# Altium schematic sheet Measuring_Current.SchDoc
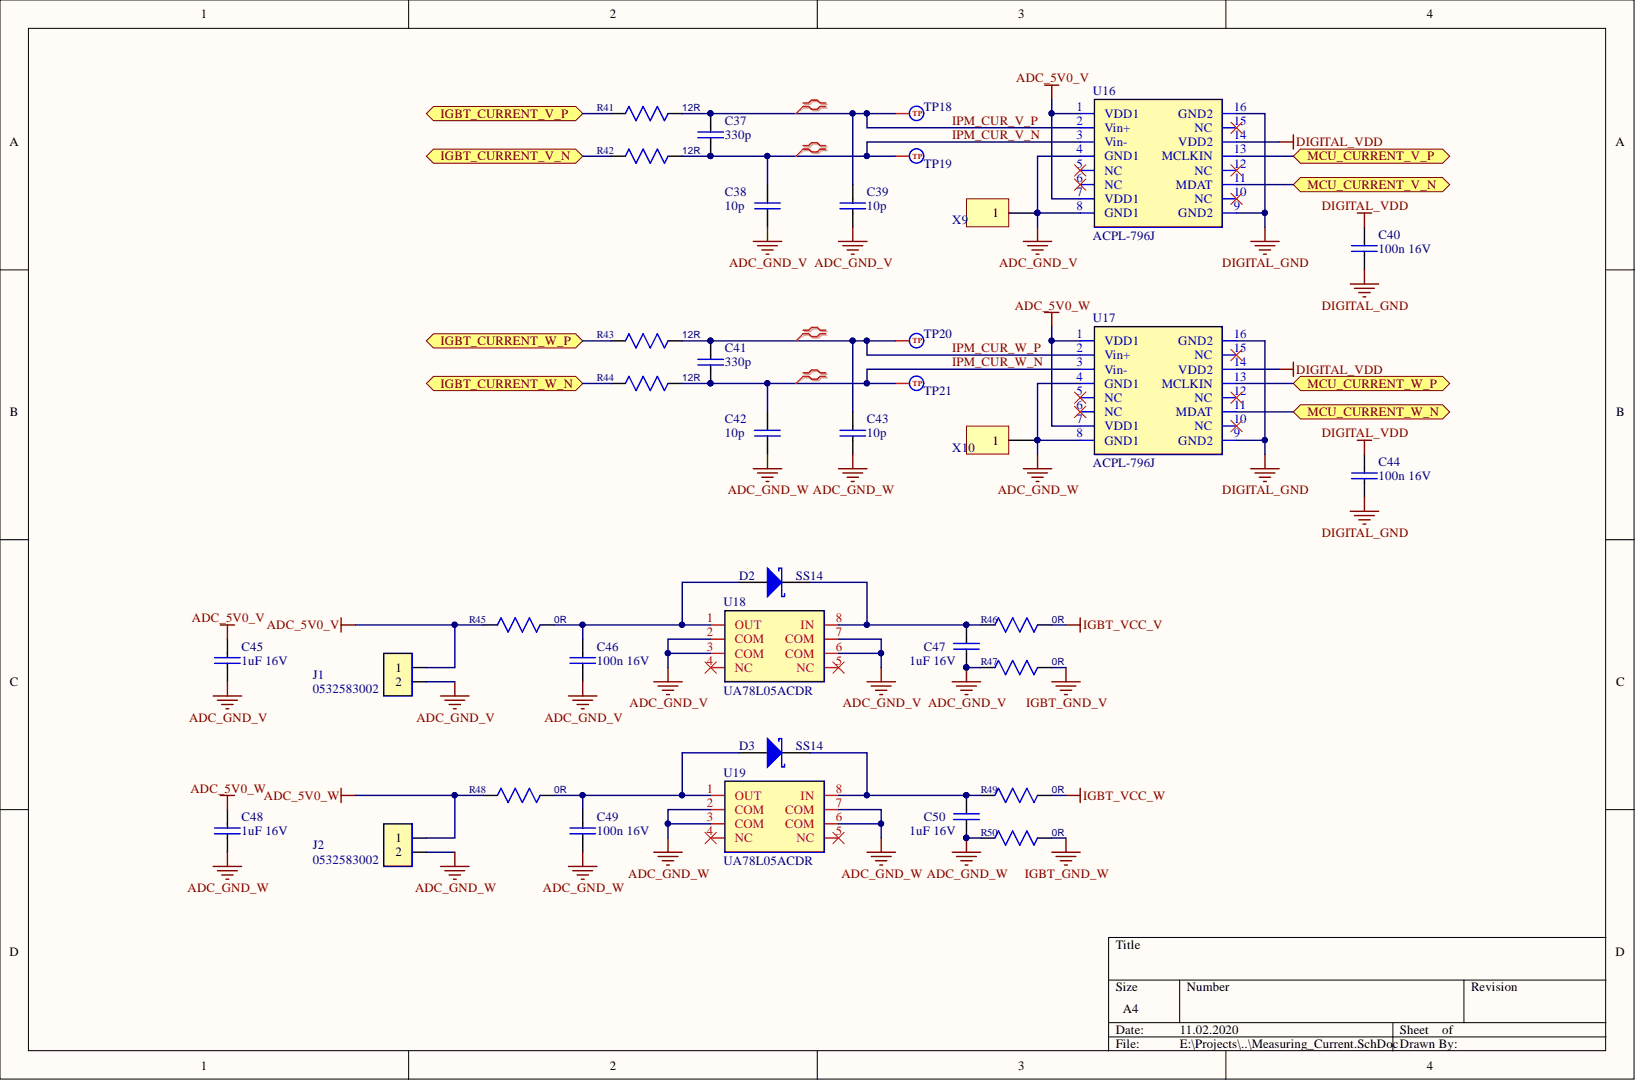
[diagram p0: Measuring_Current.SchDoc]

## Parts
C37  330p  [C_0805]
C38  10p  [C_0805]
C39  10p  [C_0805]
C40  100n 16V  [C_0805]
C41  330p  [C_0805]
C42  10p  [C_0805]
C43  10p  [C_0805]
C44  100n 16V  [C_0805]
C45  1uF 16V  [C_0805]
C46  100n 16V  [C_0805]
C47  1uF 16V  [C_0805]
C48  1uF 16V  [C_0805]
C49  100n 16V  [C_0805]
C50  1uF 16V  [C_0805]
D2  SS14  [SMA]
D3  SS14  [SMA]
J1  0532583002  [Molex 0532583002]
J2  0532583002  [Molex 0532583002]
R41  12R  [R_0805]
R42  12R  [R_0805]
R43  12R  [R_0805]
R44  12R  [R_0805]
R45  0R  [R_0805]
R46  0R  [R_0805]
R47  0R  [R_0805]
R48  0R  [R_0805]
R49  0R  [R_0805]
R50  0R  [R_0805]
TP18  TEST_POINT  [TEST POINT D1.5]  (value not drawn)
TP19  TEST_POINT  [TEST POINT D1.5]  (value not drawn)
TP20  TEST_POINT  [TEST POINT D1.5]  (value not drawn)
TP21  TEST_POINT  [TEST POINT D1.5]  (value not drawn)
U16  ACPL-796J  [SOIC-16-300]
U17  ACPL-796J  [SOIC-16-300]
U18  UA78L05ACDR  [SOIC-8_N]
U19  UA78L05ACDR  [SOIC-8_N]
X9  PLS-1  [PLS-1-3D]  (value not drawn)
X10  PLS-1  [PLS-1-3D]  (value not drawn)

## Ports
IGBT_CURRENT_V_N, IGBT_CURRENT_V_P, IGBT_CURRENT_W_N, IGBT_CURRENT_W_P, MCU_CURRENT_V_N, MCU_CURRENT_V_P, MCU_CURRENT_W_N, MCU_CURRENT_W_P

## Nets
ADC_5V0_V: C45.2 J1.1 R45.2 U16.1(VDD1) U16.7(VDD1)
ADC_5V0_W: C48.2 J2.1 R48.2 U17.1(VDD1) U17.7(VDD1)
ADC_GND_V: C38.1 C39.1 C45.1 C46.1 C47.1 J1.2 R47.1 U16.4(GND1) U16.8(GND1) U18.2(COM) U18.3(COM) U18.6(COM) U18.7(COM) X9.1
ADC_GND_W: C42.1 C43.1 C48.1 C49.1 C50.1 J2.2 R50.1 U17.4(GND1) U17.8(GND1) U19.2(COM) U19.3(COM) U19.6(COM) U19.7(COM) X10.1
DIGITAL_GND: C40.1 C44.1 U16.9(GND2) U16.16(GND2) U17.9(GND2) U17.16(GND2)
DIGITAL_VDD: C40.2 C44.2 U16.14(VDD2) U17.14(VDD2)
IGBT_GND_V: R47.2
IGBT_GND_W: R50.2
IGBT_VCC_V: R46.2
IGBT_VCC_W: R49.2
IGBT_CURRENT_V_N: R42.1 ; port IGBT_CURRENT_V_N
IGBT_CURRENT_V_P: R41.1 ; port IGBT_CURRENT_V_P
IGBT_CURRENT_W_N: R44.1 ; port IGBT_CURRENT_W_N
IGBT_CURRENT_W_P: R43.1 ; port IGBT_CURRENT_W_P
IPM_CUR_V_N: C37.1 C38.2 R42.2 TP19.1 U16.3(Vin-)
IPM_CUR_V_P: C37.2 C39.2 R41.2 TP18.1 U16.2(Vin+)
IPM_CUR_W_N: C41.1 C42.2 R44.2 TP21.1 U17.3(Vin-)
IPM_CUR_W_P: C41.2 C43.2 R43.2 TP20.1 U17.2(Vin+)
MCU_CURRENT_V_N: U16.11(MDAT) ; port MCU_CURRENT_V_N
MCU_CURRENT_V_P: U16.13(MCLKIN) ; port MCU_CURRENT_V_P
MCU_CURRENT_W_N: U17.11(MDAT) ; port MCU_CURRENT_W_N
MCU_CURRENT_W_P: U17.13(MCLKIN) ; port MCU_CURRENT_W_P
NetC46_2: C46.2 D2.1 R45.1 U18.1(OUT)
NetC47_2: C47.2 D2.2 R46.1 U18.8(IN)
NetC49_2: C49.2 D3.1 R48.1 U19.1(OUT)
NetC50_2: C50.2 D3.2 R49.1 U19.8(IN)

Not connected: U16 5(NC) 6(NC) 10(NC) 12(NC) 15(NC); U17 5(NC) 6(NC) 10(NC) 12(NC) 15(NC); U18 4(NC) 5(NC); U19 4(NC) 5(NC)

## Library data of the parts
C37-C50 = Cap NP: CERAMIC CAPACITOR; Component Kind: Standard; Library Name: Capacitors.SchLib
  C37 C41: Value: 330p
  C38 C39 C42 C43: Value: 10p
  C40 C44 C46 C49: Value: 100n 16V
  C45 C47 C48 C50: Value: 1uF 16V
D2 D3 = D Schottky: Schottky Diode; Code_JEDEC: DO-214-AA; Component Kind: Standard; LatestRevisionDate: 17-Jul-2002; LatestRevisionNote: Re-released for DXP Platform.; Library Name: Diode.SchLib; PackageDescription: DO-214-AA/SMB; 2 C-Bend Leads; Body 5.3 x 3.6 mm, inc. leads (LxW); PackageReference: SMB; Published: 8-Jun-2000; Signal Integrity: D Schottky; Simulation: SKYDIODE; Publisher: Altium Limited
J1 J2 = Molex 0532583002: CONN HEADER VERT 2POS 3.5MM; Component Kind: Standard; Library Name: Connectors.SchLib; Value: 0532583002
R41-R50 = R0805: Resistor; Component Kind: Standard; Library Name: Resistors.SchLib
  R41-R44: Value: 12R
  R45-R50: Value: 0R
TP18-TP21 = TEST_POINT: Signal Test Point; Component Kind: Standard; Library Name: Discrete.SchLib
U16 U17 = ACPL-796J: Optically Isolated Sigma-Delta Modulator; Component Kind: Standard; Library Name: AnalogToDigital.SchLib; Value: ACPL-796J
U18 U19 = UA78L05ACDR: s Positive-Voltage Linear Regulators; Component Kind: Standard; Library Name: Power.SchLib; Value: UA78L05ACDR
X9 X10 = PLS-1: Header, 1-Pin; Component Kind: Standard; LatestRevisionDate: 17-Jul-2002; LatestRevisionNote: Re-released for DXP Platform.; Library Name: Connectors.SchLib; Publisher: Altium Limited
